# Altium schematic sheet Power.SchDoc(Power) (continued)

## Library data of the parts
C1 C4 = ESL107M035AE3AA: Cap Aluminum Lytic 100uF 35V 20%( 6.3 X 11mm) Radial 2.5mm 0.085 Ohm 545mA 8000h 105C Bag; Manufacturer: Yageo Group; ESR (Equivalent Series Resistance): 85mΩ; Leakage Current: 35µA; Ripple Current: 272.5mA; Value: 100µF; Case/Package: Radial; Category: Aluminum Electrolytic Capacitors; Component Kind: Standard; ComponentLink1Description: https://datasheet.octopart.com:443/ESL107M035AE3AA-KEMET-datasheet-182055926.pdf?src-supplier=IHS; ComponentLink1URL: https://datasheet.octopart.com:443/ESL107M035AE3AA-KEMET-datasheet-182055926.pdf?src-supplier=IHS; ComponentLink2Description: https://datasheet.octopart.com:443/ESL107M035AE3AA-KEMET-datasheet-182001356.pdf?src-supplier=Farnell; ComponentLink2URL: https://datasheet.octopart.com:443/ESL107M035AE3AA-KEMET-datasheet-182001356.pdf?src-supplier=Farnell; ComponentLink3Description: https://datasheet.octopart.com:443/ESL107M035AE3AA-KEMET-datasheet-130076494.pdf?src-supplier=element14+APAC; ComponentLink3URL: https://datasheet.octopart.com:443/ESL107M035AE3AA-KEMET-datasheet-130076494.pdf?src-supplier=element14+APAC; ComponentLink4Description: https://datasheet.octopart.com:443/ESL107M035AE3AA-KEMET-datasheet-176335210.pdf?src-supplier=Newark; ComponentLink4URL: https://datasheet.octopart.com:443/ESL107M035AE3AA-KEMET-datasheet-176335210.pdf?src-supplier=Newark; ComponentLink5Description: https://datasheet.octopart.com:443/ESL107M035AE3AA-KEMET-datasheet-182427411.pdf?src-supplier=Newark; ComponentLink5URL: https://datasheet.octopart.com:443/ESL107M035AE3AA-KEMET-datasheet-182427411.pdf?src-supplier=Newark; ComponentLink6Description: https://datasheet.octopart.com:443/ESL107M035AE3AA-KEMET-datasheet-160485627.pdf?src-supplier=TME; ComponentLink6URL: https://datasheet.octopart.com:443/ESL107M035AE3AA-KEMET-datasheet-160485627.pdf?src-supplier=TME; ComponentLink7Description: https://datasheet.octopart.com:443/ESL107M035AE3AA-KEMET-datasheet-160485613.pdf?src-supplier=TME; ComponentLink7URL: https://datasheet.octopart.com:443/ESL107M035AE3AA-KEMET-datasheet-160485613.pdf?src-supplier=TME; Diameter: 6.3mm; Height: 11mm; Length: 11mm; Lifecycle Status: Production; Manufacturer Part Number: ESL107M035AE3AA; Max Operating Temperature: 105°C; Min Operating Temperature: -40°C; Mounting Technology: Radial; Number of Terminals: 2; Packaging: Bulk; Pricing: 1=0.27, 10=0.161, 50=0.1168, 100=0.1025, 500=0.07794, 1000=0.07015, 2500=0.06181, 5000=0.05673 (USD); REACH SVHC: Unaffected; RoHS: Compliant; Schedule B: 8532220020; Stock: 137190; Supplier: DigiKey; Supplier Part Number: 399-15741-ND; Terminal Pitch: 2.5mm; Tolerance: 20%; Voltage Rating (DC): 35V; Library Name: Fuzz_Pedal_Lib.SchLib
C2 = GRM31CR71E106MA12L: 25V 10uF X7R ±20% 1206 Multilayer Ceramic Capacitors MLCC - SMD/SMT ROHS; Manufacturer: Murata; Manufacturer Lifecycle Status: IN PRODUCTION; Value: 10µF; Case Code (Imperial): 1206; Case Code (Metric): 3216; Case/Package: 1206; Category: Ceramic Capacitors; Component Kind: Standard; ComponentLink1Description: https://datasheet.octopart.com:443/GRM31CR71E106MA12L-Murata-datasheet-12559435.pdf?src-supplier=element14+APAC; ComponentLink1URL: https://datasheet.octopart.com:443/GRM31CR71E106MA12L-Murata-datasheet-12559435.pdf?src-supplier=element14+APAC; ComponentLink2Description: https://datasheet.octopart.com:443/GRM31CR71E106MA12L-Murata-datasheet-62317371.pdf?src-supplier=element14+APAC; ComponentLink2URL: https://datasheet.octopart.com:443/GRM31CR71E106MA12L-Murata-datasheet-62317371.pdf?src-supplier=element14+APAC; ComponentLink3Description: https://datasheet.octopart.com:443/GRM31CR71E106MA12L-Murata-datasheet-141280605.pdf?src-supplier=Geoflip; ComponentLink3URL: https://datasheet.octopart.com:443/GRM31CR71E106MA12L-Murata-datasheet-141280605.pdf?src-supplier=Geoflip; ComponentLink4Description: https://datasheet.octopart.com:443/GRM31CR71E106MA12L-Murata-datasheet-165579666.pdf?src-supplier=TME; ComponentLink4URL: https://datasheet.octopart.com:443/GRM31CR71E106MA12L-Murata-datasheet-165579666.pdf?src-supplier=TME; ComponentLink5Description: https://datasheet.octopart.com:443/GRM31CR71E106MA12L-Murata-datasheet-140709145.pdf?src-supplier=Ciiva; ComponentLink5URL: https://datasheet.octopart.com:443/GRM31CR71E106MA12L-Murata-datasheet-140709145.pdf?src-supplier=Ciiva; ComponentLink6Description: https://datasheet.octopart.com:443/GRM31CR71E106MA12L-Murata-datasheet-138746392.pdf?src-supplier=Ciiva; ComponentLink6URL: https://datasheet.octopart.com:443/GRM31CR71E106MA12L-Murata-datasheet-138746392.pdf?src-supplier=Ciiva; ComponentLink7Description: https://datasheet.octopart.com:443/GRM31CR71E106MA12L-Murata-datasheet-22122223.pdf?src-supplier=Future+Electronics; ComponentLink7URL: https://datasheet.octopart.com:443/GRM31CR71E106MA12L-Murata-datasheet-22122223.pdf?src-supplier=Future+Electronics; ComponentLink8Description: https://datasheet.octopart.com/GRM31CR71E106MA12L-Murata-datasheet-12515295.pdf; ComponentLink8URL: https://datasheet.octopart.com/GRM31CR71E106MA12L-Murata-datasheet-12515295.pdf; ComponentLink9Description: https://datasheet.octopart.com/GRM31CR71E106MA12L-Murata-datasheet-12510076.pdf; ComponentLink9URL: https://datasheet.octopart.com/GRM31CR71E106MA12L-Murata-datasheet-12510076.pdf; ComponentLink10Description: https://datasheet.octopart.com/GRM31CR71E106MA12L-Murata-datasheet-11978611.pdf; ComponentLink10URL: https://datasheet.octopart.com/GRM31CR71E106MA12L-Murata-datasheet-11978611.pdf; ComponentLink11Description: https://datasheet.octopart.com/GRM31CR71E106MA12L-Murata-datasheet-10025737.pdf; ComponentLink11URL: https://datasheet.octopart.com/GRM31CR71E106MA12L-Murata-datasheet-10025737.pdf; ComponentLink12Description: https://datasheet.octopart.com/GRM31CR71E106MA12L-Murata-datasheet-8819587.pdf; ComponentLink12URL: https://datasheet.octopart.com/GRM31CR71E106MA12L-Murata-datasheet-8819587.pdf; ComponentLink13Description: https://datasheet.octopart.com/GRM31CR71E106MA12L-Murata-datasheet-14397760.pdf; ComponentLink13URL: https://datasheet.octopart.com/GRM31CR71E106MA12L-Murata-datasheet-14397760.pdf; ComponentLink14Description: https://datasheet.octopart.com:443/GRM31CR71E106MA12L-Murata-datasheet-150009226.pdf?src-supplier=Arrow.cn; ComponentLink14URL: https://datasheet.octopart.com:443/GRM31CR71E106MA12L-Murata-datasheet-150009226.pdf?src-supplier=Arrow.cn; ComponentLink15Description: http://sigma.octopart.com:80/reach_statement/Murata-company-16.pdf?src-supplier=Compliance+Statements; ComponentLink15URL: http://sigma.octopart.com:80/reach_statement/Murata-company-16.pdf?src-supplier=Compliance+Statements; Depth: 1.6mm; Dielectric: X7R; Dielectric Material: Ceramic; Export Control Classification Number (ECCN) Code: EAR99; Harmonized Tariff Schedule (HTS) Code: 8532.24.00.20; Height: 1.6mm; Introduction Date: 2008-08-22; Lead Free: Lead Free; Length: 3.2mm; Lifecycle Status: Production; Manufacturer Part Number: GRM31CR71E106MA12L; Material: Ceramic; Max Operating Temperature: 125°C; Min Operating Temperature: -55°C; Mounting Technology: Surface Mount; Number of Terminals: 2; Packaging: Cut Tape; Pricing: 1=0.35, 10=0.205, 50=0.1492, 100=0.1321, 500=0.10336 (USD); Radiation Hardening: No; REACH SVHC: Unaffected; RoHS: Compliant; Schedule B: 8532240020, 8532240020|8532240020, 8532240020|8532240020|8532240020, 8532240020|8532240020|8532240020|8532240020; Stock: 60425; Supplier: DigiKey; Supplier Part Number: 490-6519-6-ND; Termination: SMD/SMT; Thickness: 1.6mm; Tolerance: 20%; Voltage: 25V; Voltage Rating: 25V; Voltage Rating (DC): 25V; Width: 1.6mm; Library Name: Fuzz_Pedal_Lib.SchLib
C3 C5 = C0805C104K5RACTU: 50V 100nF X7R ±10% 0805 Multilayer Ceramic Capacitors MLCC - SMD/SMT ROHS; Manufacturer: Yageo Group; Temperature Coefficient: 30ppm/°C; Voltage: 500V; Case Code (Imperial): 0805; Case/Package: 0805; Category: Ceramic Capacitors; China RoHS: Compliant; Component Kind: Standard; ComponentLink1Description: https://datasheet.octopart.com:443/C0805C104K5RACTU-KEMET-datasheet-178445679.pdf?src-supplier=IHS; ComponentLink1URL: https://datasheet.octopart.com:443/C0805C104K5RACTU-KEMET-datasheet-178445679.pdf?src-supplier=IHS; ComponentLink2Description: https://datasheet.octopart.com:443/C0805C104K5RAC7800-KEMET-datasheet-182041128.pdf?src-supplier=IHS; ComponentLink2URL: https://datasheet.octopart.com:443/C0805C104K5RAC7800-KEMET-datasheet-182041128.pdf?src-supplier=IHS; ComponentLink3Description: https://datasheet.octopart.com:443/C0805C104K5RACTU-KEMET-datasheet-137357759.pdf?src-supplier=Upverter; ComponentLink3URL: https://datasheet.octopart.com:443/C0805C104K5RACTU-KEMET-datasheet-137357759.pdf?src-supplier=Upverter; ComponentLink4Description: https://datasheet.octopart.com:443/C0805C104K5RACTU-KEMET-datasheet-68331921.pdf?src-supplier=Upverter; ComponentLink4URL: https://datasheet.octopart.com:443/C0805C104K5RACTU-KEMET-datasheet-68331921.pdf?src-supplier=Upverter; ComponentLink5Description: https://datasheet.octopart.com:443/C0805C104K5RAC-TU-Kemet-datasheet-5313890.pdf?src-supplier=Newark; ComponentLink5URL: https://datasheet.octopart.com:443/C0805C104K5RAC-TU-Kemet-datasheet-5313890.pdf?src-supplier=Newark; ComponentLink6Description: https://datasheet.octopart.com:443/C0805C104K5RAC-TU-Kemet-datasheet-5390537.pdf?src-supplier=Newark; ComponentLink6URL: https://datasheet.octopart.com:443/C0805C104K5RAC-TU-Kemet-datasheet-5390537.pdf?src-supplier=Newark; ComponentLink7Description: https://datasheet.octopart.com:443/C0805C104K5RAC-TU-Kemet-datasheet-7541751.pdf?src-supplier=Newark; ComponentLink7URL: https://datasheet.octopart.com:443/C0805C104K5RAC-TU-Kemet-datasheet-7541751.pdf?src-supplier=Newark; ComponentLink8Description: https://datasheet.octopart.com:443/C0805C104K5RACTU-KEMET-datasheet-170667449.pdf?src-supplier=Newark; ComponentLink8URL: https://datasheet.octopart.com:443/C0805C104K5RACTU-KEMET-datasheet-170667449.pdf?src-supplier=Newark; ComponentLink9Description: https://datasheet.octopart.com:443/C0805C104K5RACTU-Kemet-datasheet-14356739.pdf?src-supplier=Newark; ComponentLink9URL: https://datasheet.octopart.com:443/C0805C104K5RACTU-Kemet-datasheet-14356739.pdf?src-supplier=Newark; ComponentLink10Description: https://datasheet.octopart.com:443/C0805C104K5RACTU-Kemet-datasheet-8764837.pdf?src-supplier=Newark; ComponentLink10URL: https://datasheet.octopart.com:443/C0805C104K5RACTU-Kemet-datasheet-8764837.pdf?src-supplier=Newark; ComponentLink11Description: https://datasheet.octopart.com:443/C0805C104K5RACTU-Kemet-datasheet-8480123.pdf?src-supplier=Newark; ComponentLink11URL: https://datasheet.octopart.com:443/C0805C104K5RACTU-Kemet-datasheet-8480123.pdf?src-supplier=Newark; ComponentLink12Description: https://datasheet.octopart.com:443/C0805C104K5RACTU-Kemet-datasheet-5393970.pdf?src-supplier=Newark; ComponentLink12URL: https://datasheet.octopart.com:443/C0805C104K5RACTU-Kemet-datasheet-5393970.pdf?src-supplier=Newark; ComponentLink13Description: https://datasheet.octopart.com:443/C0805C104K5RACTU-Kemet-datasheet-8502025.pdf?src-supplier=Newark; ComponentLink13URL: https://datasheet.octopart.com:443/C0805C104K5RACTU-Kemet-datasheet-8502025.pdf?src-supplier=Newark; ComponentLink14Description: https://datasheet.octopart.com:443/C0805C104K5RACTU-Kemet-datasheet-161801.pdf?src-supplier=Newark; ComponentLink14URL: https://datasheet.octopart.com:443/C0805C104K5RACTU-Kemet-datasheet-161801.pdf?src-supplier=Newark; ComponentLink15Description: https://datasheet.octopart.com:443/C0805C104K5RACTU-KEMET-datasheet-101576148.pdf?src-supplier=Farnell; ComponentLink15URL: https://datasheet.octopart.com:443/C0805C104K5RACTU-KEMET-datasheet-101576148.pdf?src-supplier=Farnell; ComponentLink16Description: https://datasheet.octopart.com:443/C0805C104K5RACTU-KEMET-datasheet-176142297.pdf?src-supplier=Farnell; ComponentLink16URL: https://datasheet.octopart.com:443/C0805C104K5RACTU-KEMET-datasheet-176142297.pdf?src-supplier=Farnell; ComponentLink17Description: https://datasheet.octopart.com:443/C0805C104K5RAC-TU-Kemet-datasheet-12608295.pdf?src-supplier=Farnell; ComponentLink17URL: https://datasheet.octopart.com:443/C0805C104K5RAC-TU-Kemet-datasheet-12608295.pdf?src-supplier=Farnell; ComponentLink18Description: https://datasheet.octopart.com:443/C0805C104K5RAC-TU-Kemet-datasheet-11992184.pdf?src-supplier=Farnell; ComponentLink18URL: https://datasheet.octopart.com:443/C0805C104K5RAC-TU-Kemet-datasheet-11992184.pdf?src-supplier=Farnell; ComponentLink19Description: https://datasheet.octopart.com:443/C0805C104K5RAC-TU-Kemet-datasheet-10942409.pdf?src-supplier=Farnell; ComponentLink19URL: https://datasheet.octopart.com:443/C0805C104K5RAC-TU-Kemet-datasheet-10942409.pdf?src-supplier=Farnell; ComponentLink20Description: https://datasheet.octopart.com:443/C0805C104K5RAC-TU-Kemet-datasheet-10404442.pdf?src-supplier=Farnell; ComponentLink20URL: https://datasheet.octopart.com:443/C0805C104K5RAC-TU-Kemet-datasheet-10404442.pdf?src-supplier=Farnell; ComponentLink21Description: https://datasheet.octopart.com:443/C0805C104K5RAC-TU-Kemet-datasheet-7833651.pdf?src-supplier=Farnell; ComponentLink21URL: https://datasheet.octopart.com:443/C0805C104K5RAC-TU-Kemet-datasheet-7833651.pdf?src-supplier=Farnell; ComponentLink22Description: https://datasheet.octopart.com:443/C0805C104K5RAC-TU-Kemet-datasheet-7601896.pdf?src-supplier=Farnell; ComponentLink22URL: https://datasheet.octopart.com:443/C0805C104K5RAC-TU-Kemet-datasheet-7601896.pdf?src-supplier=Farnell; ComponentLink23Description: https://datasheet.octopart.com:443/C0805C104K5RAC-TU-Kemet-datasheet-5316294.pdf?src-supplier=Farnell; ComponentLink23URL: https://datasheet.octopart.com:443/C0805C104K5RAC-TU-Kemet-datasheet-5316294.pdf?src-supplier=Farnell; ComponentLink24Description: https://datasheet.octopart.com:443/C0805C104K5RAC-TU-Kemet-datasheet-5314837.pdf?src-supplier=Farnell; ComponentLink24URL: https://datasheet.octopart.com:443/C0805C104K5RAC-TU-Kemet-datasheet-5314837.pdf?src-supplier=Farnell; ComponentLink25Description: https://datasheet.octopart.com:443/C0805C104K5RAC-TU-Kemet-datasheet-8092359.pdf?src-supplier=Farnell; ComponentLink25URL: https://datasheet.octopart.com:443/C0805C104K5RAC-TU-Kemet-datasheet-8092359.pdf?src-supplier=Farnell; ComponentLink26Description: https://datasheet.octopart.com:443/C0805C104K5RACTU-Kemet-datasheet-5314449.pdf?src-supplier=Farnell; ComponentLink26URL: https://datasheet.octopart.com:443/C0805C104K5RACTU-Kemet-datasheet-5314449.pdf?src-supplier=Farnell; ComponentLink27Description: https://datasheet.octopart.com:443/C0805C104K5RAC-TU-Kemet-datasheet-5314969.pdf?src-supplier=Farnell; ComponentLink27URL: https://datasheet.octopart.com:443/C0805C104K5RAC-TU-Kemet-datasheet-5314969.pdf?src-supplier=Farnell; ComponentLink28Description: https://datasheet.octopart.com:443/C0805C104K5RAC-TU-Kemet-datasheet-5314627.pdf?src-supplier=Farnell; ComponentLink28URL: https://datasheet.octopart.com:443/C0805C104K5RAC-TU-Kemet-datasheet-5314627.pdf?src-supplier=Farnell; ComponentLink29Description: https://datasheet.octopart.com:443/C0805C104K5RAC-TU-Kemet-datasheet-5389132.pdf?src-supplier=Farnell; ComponentLink29URL: https://datasheet.octopart.com:443/C0805C104K5RAC-TU-Kemet-datasheet-5389132.pdf?src-supplier=Farnell; ComponentLink30Description: https://datasheet.octopart.com:443/C0805C104K5RAC-TU-Kemet-datasheet-5313836.pdf?src-supplier=Farnell; ComponentLink30URL: https://datasheet.octopart.com:443/C0805C104K5RAC-TU-Kemet-datasheet-5313836.pdf?src-supplier=Farnell; ComponentLink31Description: https://datasheet.octopart.com:443/C0805C104K5RAC-TU-Kemet-datasheet-8111010.pdf?src-supplier=Farnell; ComponentLink31URL: https://datasheet.octopart.com:443/C0805C104K5RAC-TU-Kemet-datasheet-8111010.pdf?src-supplier=Farnell; ComponentLink32Description: https://datasheet.octopart.com:443/C0805C104K5RAC-TU-Kemet-datasheet-7627733.pdf?src-supplier=Farnell; ComponentLink32URL: https://datasheet.octopart.com:443/C0805C104K5RAC-TU-Kemet-datasheet-7627733.pdf?src-supplier=Farnell; ComponentLink33Description: https://datasheet.octopart.com:443/C0805C104K5RAC-TU-Kemet-datasheet-5315946.pdf?src-supplier=Farnell; ComponentLink33URL: https://datasheet.octopart.com:443/C0805C104K5RAC-TU-Kemet-datasheet-5315946.pdf?src-supplier=Farnell; ComponentLink34Description: https://datasheet.octopart.com:443/C0805C104K5RACTU-KEMET-datasheet-37550250.pdf?src-supplier=Electro+Sonic; ComponentLink34URL: https://datasheet.octopart.com:443/C0805C104K5RACTU-KEMET-datasheet-37550250.pdf?src-supplier=Electro+Sonic; ComponentLink35Description: https://datasheet.octopart.com:443/C0805C104K5RAC-TU-Kemet-datasheet-32036385.pdf?src-supplier=element14+APAC; ComponentLink35URL: https://datasheet.octopart.com:443/C0805C104K5RAC-TU-Kemet-datasheet-32036385.pdf?src-supplier=element14+APAC; ComponentLink36Description: https://datasheet.octopart.com:443/C0805C104K5RACTU-Kemet-datasheet-22975000.pdf?src-supplier=element14+APAC; ComponentLink36URL: https://datasheet.octopart.com:443/C0805C104K5RACTU-Kemet-datasheet-22975000.pdf?src-supplier=element14+APAC; ComponentLink37Description: https://datasheet.octopart.com:443/C0805C104K5RACTU-Kemet-datasheet-22084244.pdf?src-supplier=element14+APAC; ComponentLink37URL: https://datasheet.octopart.com:443/C0805C104K5RACTU-Kemet-datasheet-22084244.pdf?src-supplier=element14+APAC; ComponentLink38Description: https://datasheet.octopart.com:443/C0805C104K5RAC7800-KEMET-datasheet-188512054.pdf?src-supplier=ODG+(Origin+Data+Global); ComponentLink38URL: https://datasheet.octopart.com:443/C0805C104K5RAC7800-KEMET-datasheet-188512054.pdf?src-supplier=ODG+(Origin+Data+Global); ComponentLink39Description: https://datasheet.octopart.com:443/C0805C104K5RACTU-KEMET-datasheet-164512540.pdf?src-supplier=TME; ComponentLink39URL: https://datasheet.octopart.com:443/C0805C104K5RACTU-KEMET-datasheet-164512540.pdf?src-supplier=TME; ComponentLink40Description: https://datasheet.octopart.com:443/C0805C104K5RACTU-Kemet-datasheet-67847106.pdf?src-supplier=TME; ComponentLink40URL: https://datasheet.octopart.com:443/C0805C104K5RACTU-Kemet-datasheet-67847106.pdf?src-supplier=TME; ComponentLink41Description: https://datasheet.octopart.com:443/C0805C104K5RACTU-KEMET-datasheet-68331906.pdf?src-supplier=TME; ComponentLink41URL: https://datasheet.octopart.com:443/C0805C104K5RACTU-KEMET-datasheet-68331906.pdf?src-supplier=TME; ComponentLink42Description: https://datasheet.octopart.com:443/C0805C104K5RACTU-KEMET-datasheet-110724412.pdf?src-supplier=RS+(Formerly+Allied+Electronics); ComponentLink42URL: https://datasheet.octopart.com:443/C0805C104K5RACTU-KEMET-datasheet-110724412.pdf?src-supplier=RS+(Formerly+Allied+Electronics); ComponentLink43Description: https://datasheet.octopart.com:443/C0805C104K5RACTU-Kemet-datasheet-464.pdf?src-supplier=RS+(Formerly+Allied+Electronics); ComponentLink43URL: https://datasheet.octopart.com:443/C0805C104K5RACTU-Kemet-datasheet-464.pdf?src-supplier=RS+(Formerly+Allied+Electronics); ComponentLink44Description: https://datasheet.octopart.com/C0805C104K5RACTU-KEMET-datasheet-84169754.pdf; ComponentLink44URL: https://datasheet.octopart.com/C0805C104K5RACTU-KEMET-datasheet-84169754.pdf; ComponentLink45Description: https://datasheet.octopart.com:443/C0805C104K5RACTU-Kemet-datasheet-13693438.pdf?src-supplier=Factory+Futures; ComponentLink45URL: https://datasheet.octopart.com:443/C0805C104K5RACTU-Kemet-datasheet-13693438.pdf?src-supplier=Factory+Futures; ComponentLink46Description: https://datasheet.octopart.com:443/C0805C104K5RAC7800-KEMET-datasheet-164857143.pdf?src-supplier=Burklin+Elektronik; ComponentLink46URL: https://datasheet.octopart.com:443/C0805C104K5RAC7800-KEMET-datasheet-164857143.pdf?src-supplier=Burklin+Elektronik; ComponentLink47Description: http://sigma.octopart.com:80/conflict_mineral_statement/Yageo-company-3.pdf?src-supplier=Compliance+Statements; ComponentLink47URL: http://sigma.octopart.com:80/conflict_mineral_statement/Yageo-company-3.pdf?src-supplier=Compliance+Statements; ComponentLink48Description: http://sigma.octopart.com:80/rohs_statement/Yageo-company-2.pdf?src-supplier=Compliance+Statements; ComponentLink48URL: http://sigma.octopart.com:80/rohs_statement/Yageo-company-2.pdf?src-supplier=Compliance+Statements; Contact Plating: Tin; Country of Origin: Mainland China; Depth: 1.25mm; Dielectric: X7R; Dielectric Material: Ceramic; Export Control Classification Number (ECCN) Code: EAR99; Harmonized Tariff Schedule (HTS) Code: 8532.24.00.20; Height: 780µm; Introduction Date: 2001-04-27; Lead Free: Lead Free; Lead Pitch: 750µm; Length: 2mm; Lifecycle Status: Production; Manufacturer Part Number: C0805C104K5RACTU; Material: Ceramic; Max Operating Temperature: 125°C; Min Operating Temperature: -55°C; Mounting Technology: Surface Mount; Number of Terminals: 2; Packaging: Cut Tape; Pins: 2; Pricing: 1=0.18, 10=0.1, 50=0.07, 100=0.0608, 500=0.0454 (USD); Radiation Hardening: No; REACH SVHC: Unaffected; RoHS: Compliant; Schedule B: 8532240020, 8532240020|8532240020, 8532240020|8532240020|8532240020, 8532240020|8532240020|8532240020|8532240020; Stock: 6696372; Supplier: DigiKey; Supplier Part Number: 399-C0805C104K5RACTUDKR-ND; Termination: SMD/SMT; Thickness: 889µm; Tolerance: 10%; Value: 100nF; Voltage Rating: 50V; Voltage Rating (DC): 50V; Width: 1.25mm; Library Name: Fuzz_Pedal_Lib.SchLib
D1 D2 = WP1503GT: Single Color LED, Green, Transparent, T-1 3/4, 5mm; Manufacturer: Kingbright; Dominant Wavelength: 568nm; Forward Current: 25mA; Forward Voltage: 2.2V; Lens Style: Clear, Dome, Tinted; Luminous Intensity: 60mcd; Number of Circuits: 1; Peak Wavelength: 565nm; Shape: Round; Test Current: 20mA; Viewing Angle: 30°; Case/Package: Radial; Category: LEDs; China RoHS: Compliant; Color: Green; Component Kind: Standard; ComponentLink1Description: https://datasheet.octopart.com:443/WP1503GT-Kingbright-datasheet-180525248.pdf?src-supplier=IHS; ComponentLink1URL: https://datasheet.octopart.com:443/WP1503GT-Kingbright-datasheet-180525248.pdf?src-supplier=IHS; ComponentLink2Description: https://datasheet.octopart.com:443/WP1503GT-Kingbright-datasheet-65593620.pdf?src-supplier=IHS; ComponentLink2URL: https://datasheet.octopart.com:443/WP1503GT-Kingbright-datasheet-65593620.pdf?src-supplier=IHS; ComponentLink3Description: https://datasheet.octopart.com:443/WP1503GT-Kingbright-datasheet-137343189.pdf?src-supplier=Kingbright+SCT; ComponentLink3URL: https://datasheet.octopart.com:443/WP1503GT-Kingbright-datasheet-137343189.pdf?src-supplier=Kingbright+SCT; Country of Origin: Mainland China; Harmonized Tariff Schedule (HTS) Code: 8541.40.20.00; Height: 8.6mm; Illumination Color: Green; Introduction Date: 2005-04-16; Lens Color: Green; Lifecycle Status: Production; Manufacturer Part Number: WP1503GT; Max Operating Temperature: 85°C; Min Operating Temperature: -40°C; Mounting Technology: Through Hole; Number of Terminals: 2; Packaging: Bulk; Pricing: 1=0.24, 10=0.166, 100=0.116, 500=0.09322, 1000=0.08569, 2000=0.07926, 5000=0.0722, 20000=0.06403, 40000=0.06085 (USD); REACH SVHC: Yes; RoHS: Compliant; Stock: 14499; Supplier: DigiKey; Supplier Part Number: WP1503GT-ND; Terminal Pitch: 2.54mm; Wavelength: 568nm; Library Name: EcoCar_Accessory_Controller_V2.IntLib
D3 = SAC10-E3/54: 500 W 10 V Uni Directional Axial Transient Voltage Suppressor - DO-204AC; Manufacturer: Vishay; Breakdown Voltage: 11.1V; Clamping Voltage: 1V; Element Configuration: Single; Leakage Current: 5µA; Max Power Dissipation: 3W; Max Surge Current: 29A; Number of Channels: 1; Operating Supply Voltage: 10V; Peak Pulse Power: 500W; Test Current: 1mA; Value: 50pF; Case/Package: DO-15; Category: TVS Diodes; China RoHS: Non-Compliant; Component Kind: Standard; ComponentLink1Description: https://datasheet.octopart.com:443/SAC10-E3-54-Vishay-datasheet-181470670.pdf?src-supplier=Newark; ComponentLink1URL: https://datasheet.octopart.com:443/SAC10-E3-54-Vishay-datasheet-181470670.pdf?src-supplier=Newark; ComponentLink2Description: https://datasheet.octopart.com:443/SAC10-E3/54-Vishay-datasheet-11555040.pdf?src-supplier=Newark; ComponentLink2URL: https://datasheet.octopart.com:443/SAC10-E3/54-Vishay-datasheet-11555040.pdf?src-supplier=Newark; ComponentLink3Description: https://datasheet.octopart.com:443/SAC10-E3/54-Vishay-datasheet-8373145.pdf?src-supplier=Newark; ComponentLink3URL: https://datasheet.octopart.com:443/SAC10-E3/54-Vishay-datasheet-8373145.pdf?src-supplier=Newark; ComponentLink4Description: https://datasheet.octopart.com:443/SAC10-E3/54-Vishay-datasheet-5316468.pdf?src-supplier=Newark; ComponentLink4URL: https://datasheet.octopart.com:443/SAC10-E3/54-Vishay-datasheet-5316468.pdf?src-supplier=Newark; ComponentLink5Description: https://datasheet.octopart.com:443/SAC10-E3-54-Vishay-datasheet-78862583.pdf?src-supplier=Newark; ComponentLink5URL: https://datasheet.octopart.com:443/SAC10-E3-54-Vishay-datasheet-78862583.pdf?src-supplier=Newark; ComponentLink6Description: https://datasheet.octopart.com:443/SAC10-E3-54-Vishay-datasheet-33014114.pdf?src-supplier=Newark; ComponentLink6URL: https://datasheet.octopart.com:443/SAC10-E3-54-Vishay-datasheet-33014114.pdf?src-supplier=Newark; ComponentLink7Description: http://sigma.octopart.com:80/rohs_statement/Vishay-company-15.pdf?src-supplier=Compliance+Statements; ComponentLink7URL: http://sigma.octopart.com:80/rohs_statement/Vishay-company-15.pdf?src-supplier=Compliance+Statements; Direction: Unidirectional; Lead Free: Lead Free; Lifecycle Status: Production; Manufacturer Part Number: SAC10-E3/54; Max Operating Temperature: 175°C; Max Reverse Leakage Current: 5µA; Min Breakdown Voltage: 11.1V; Min Operating Temperature: -55°C; Mounting Technology: Through Hole; Number of Elements: 1; Number of Terminals: 2; Peak Pulse Current: 29A; Pins: 2; Polarity: Unidirectional; Power Dissipation: 500W; Pricing: 1=1.96, 10=1.247, 50=0.9376, 100=0.8377, 500=0.66244 (USD); REACH SVHC: Affected; Reverse Breakdown Voltage: 11.1V; Reverse Standoff Voltage: 10V; RoHS: Compliant; Stock: 365; Supplier: DigiKey; Supplier Part Number: 112-SAC10-E3/54CT-ND; Termination: Axial; Library Name: Tremolo_Pedal.IntLib
FB1 = MPZ2012S601AT000: Ferrite Beads Multi-Layer Power 600Ohm 25% 100MHz 2A 0.1Ohm DCR 0805 T/R; Manufacturer: TDK; Manufacturer Lifecycle Status: PRODUCTION; Manufacturer Package Identifier: 0805 (2012 metric); DC Resistance (DCR): 100mΩ; Impedance: 600Ω; Number of Circuits: 1; Value: 100mΩ; Case Code (Imperial): 0805; Case Code (Metric): 2012; Case/Package: 0805; Category: Ferrite Beads and Chips; China RoHS: Compliant; Clock Frequency: 100MHz; Component Kind: Standard; ComponentLink1Description: https://datasheet.octopart.com:443/MPZ2012S601AT000-TDK-datasheet-155380320.pdf?src-supplier=ODG+(Origin+Data+Global); ComponentLink1URL: https://datasheet.octopart.com:443/MPZ2012S601AT000-TDK-datasheet-155380320.pdf?src-supplier=ODG+(Origin+Data+Global); ComponentLink2Description: https://datasheet.octopart.com:443/MPZ2012S601AT000-TDK-datasheet-166558464.pdf?src-supplier=Farnell; ComponentLink2URL: https://datasheet.octopart.com:443/MPZ2012S601AT000-TDK-datasheet-166558464.pdf?src-supplier=Farnell; ComponentLink3Description: https://datasheet.octopart.com:443/MPZ2012S601AT000-TDK-datasheet-12512619.pdf?src-supplier=Farnell; ComponentLink3URL: https://datasheet.octopart.com:443/MPZ2012S601AT000-TDK-datasheet-12512619.pdf?src-supplier=Farnell; ComponentLink4Description: https://datasheet.octopart.com:443/MPZ2012S601AT000-TDK-datasheet-8365394.pdf?src-supplier=Farnell; ComponentLink4URL: https://datasheet.octopart.com:443/MPZ2012S601AT000-TDK-datasheet-8365394.pdf?src-supplier=Farnell; ComponentLink5Description: https://datasheet.octopart.com:443/MPZ2012S601AT000-TDK-datasheet-7554990.pdf?src-supplier=Farnell; ComponentLink5URL: https://datasheet.octopart.com:443/MPZ2012S601AT000-TDK-datasheet-7554990.pdf?src-supplier=Farnell; ComponentLink6Description: https://datasheet.octopart.com:443/MPZ2012S601AT000-TDK-datasheet-39118740.pdf?src-supplier=Upverter; ComponentLink6URL: https://datasheet.octopart.com:443/MPZ2012S601AT000-TDK-datasheet-39118740.pdf?src-supplier=Upverter; ComponentLink7Description: https://datasheet.octopart.com:443/MPZ2012S601AT000-TDK-datasheet-82475261.pdf?src-supplier=Newark; ComponentLink7URL: https://datasheet.octopart.com:443/MPZ2012S601AT000-TDK-datasheet-82475261.pdf?src-supplier=Newark; ComponentLink8Description: https://datasheet.octopart.com:443/MPZ2012S601AT000-TDK-datasheet-41739209.pdf?src-supplier=Newark; ComponentLink8URL: https://datasheet.octopart.com:443/MPZ2012S601AT000-TDK-datasheet-41739209.pdf?src-supplier=Newark; ComponentLink9Description: https://datasheet.octopart.com:443/MPZ2012S601AT000-TDK-datasheet-21218011.pdf?src-supplier=Newark; ComponentLink9URL: https://datasheet.octopart.com:443/MPZ2012S601AT000-TDK-datasheet-21218011.pdf?src-supplier=Newark; ComponentLink10Description: https://datasheet.octopart.com:443/MPZ2012S601AT000-TDK-datasheet-21631858.pdf?src-supplier=Future+Electronics; ComponentLink10URL: https://datasheet.octopart.com:443/MPZ2012S601AT000-TDK-datasheet-21631858.pdf?src-supplier=Future+Electronics; ComponentLink11Description: https://datasheet.octopart.com:443/MPZ2012S601AT000-TDK-datasheet-62109747.pdf?src-supplier=Electro+Sonic; ComponentLink11URL: https://datasheet.octopart.com:443/MPZ2012S601AT000-TDK-datasheet-62109747.pdf?src-supplier=Electro+Sonic; ComponentLink12Description: https://datasheet.octopart.com:443/MPZ2012S601AT000-TDK-datasheet-155118627.pdf?src-supplier=Master+Electronics; ComponentLink12URL: https://datasheet.octopart.com:443/MPZ2012S601AT000-TDK-datasheet-155118627.pdf?src-supplier=Master+Electronics; Core Material: Ferrite; Current Rating: 2A; ESR: 100mΩ; Export Control Classification Number (ECCN) Code: EAR99; Harmonized Tariff Schedule (HTS) Code: 8504.50.80.00; Height: 850µm; Introduction Date: 2009-03-27; Lead Free: Lead Free; Length: 2mm; Lifecycle Status: Production; Manufacturer Part Number: MPZ2012S601AT000; Max DC Current: 2A; Max Length: 2.2mm; Max Operating Temperature: 125°C; Max Width: 1.45mm; Min Length: 1.8mm; Min Operating Temperature: -55°C; Min Width: 1.05mm; Mounting Technology: Surface Mount; Packaging: Tape and Reel; Pricing: 1=0.11, 10=0.077, 25=0.0664, 50=0.0598, 100=0.0536, 250=0.04612, 500=0.04098, 1000=0.03622, 4000=0.02695, 8000=0.02325, 12000=0.02225 (USD); REACH SVHC: Unaffected; RMS Current (Irms): 2A; RoHS: Compliant; Schedule B: 8504500000, 8504500000|8504500000|8504500000|8504500000|8504500000; Stock: 1114236; Supplier: DigiKey; Supplier Part Number: 445-MPZ2012S601AT000CT-ND; Test Frequency: 100MHz; Tolerance: 25%; Width: 1.25mm; Library Name: Fuzz_Pedal_Lib.SchLib
J1 = 54-00129: Conn DC Power RCP 2 POS Solder RA Thru-Hole 3 Terminal 1 Port; Manufacturer: Tensility; Current Rating: 6A; Voltage Rating: 48V; Category: Connectors; Component Kind: Standard; ComponentLink1Description: https://datasheet.octopart.com:443/54-00129-Tensility-datasheet-189118334.pdf?src-supplier=Master+Electronics; ComponentLink1URL: https://datasheet.octopart.com:443/54-00129-Tensility-datasheet-189118334.pdf?src-supplier=Master+Electronics; Contact Material: Brass; Export Control Classification Number (ECCN) Code: EAR99; Harmonized Tariff Schedule (HTS) Code: 8536.69.40.20; Manufacturer Part Number: 54-00129; Max Operating Temperature: 85°C; Min Operating Temperature: -25°C; Pricing: 1=0.88, 10=0.745, 25=0.6976, 50=0.6644, 100=0.6326, 250=0.59296, 500=0.56462, 1000=0.53765, 2500=0.50399 (USD); REACH SVHC: Affected; RoHS: Compliant; Schedule B: 8536695050, 8536695050|8536695050|8536695050|8536695050|8536695050; Stock: 18126; Supplier: DigiKey; Supplier Part Number: 839-54-00129-ND; Termination: Solder; Library Name: Tremolo_Pedal.IntLib
R1 R2 = RC0805FR-0710KL: 10 kOhms ±1% 0.125W, 1/8W Chip Resistor 0805 (2012 Metric) Moisture Resistant Thick Film; Manufacturer: Yageo Group; Max Power Dissipation: 125mW; Temperature Coefficient: 100ppm/°C; Value: 10kΩ; Voltage Rating: 150V; Case Code (Imperial): 0805; Case/Package: 0805; Category: Chip SMD Resistors; China RoHS: Non-Compliant; Component Kind: Standard; ComponentLink1Description: https://datasheet.octopart.com:443/RC0805FR-0710KL-Yageo-datasheet-175887523.pdf?src-supplier=Newark; ComponentLink1URL: https://datasheet.octopart.com:443/RC0805FR-0710KL-Yageo-datasheet-175887523.pdf?src-supplier=Newark; ComponentLink2Description: https://datasheet.octopart.com:443/RC0805FR-0710KL-Yageo-datasheet-62067350.pdf?src-supplier=Farnell; ComponentLink2URL: https://datasheet.octopart.com:443/RC0805FR-0710KL-Yageo-datasheet-62067350.pdf?src-supplier=Farnell; ComponentLink3Description: https://datasheet.octopart.com:443/RC0805FR-0710KL-Yageo-datasheet-13691069.pdf?src-supplier=Farnell; ComponentLink3URL: https://datasheet.octopart.com:443/RC0805FR-0710KL-Yageo-datasheet-13691069.pdf?src-supplier=Farnell; ComponentLink4Description: https://datasheet.octopart.com:443/RC0805FR-0710KL-Yageo-datasheet-103092701.pdf?src-supplier=Upverter; ComponentLink4URL: https://datasheet.octopart.com:443/RC0805FR-0710KL-Yageo-datasheet-103092701.pdf?src-supplier=Upverter; ComponentLink5Description: https://datasheet.octopart.com:443/RC0805FR-0710KL-Yageo-datasheet-98239111.pdf?src-supplier=Upverter; ComponentLink5URL: https://datasheet.octopart.com:443/RC0805FR-0710KL-Yageo-datasheet-98239111.pdf?src-supplier=Upverter; ComponentLink6Description: https://datasheet.octopart.com:443/RC0805FR-0710KL-Yageo---Phycomp-datasheet-67302840.pdf?src-supplier=Upverter; ComponentLink6URL: https://datasheet.octopart.com:443/RC0805FR-0710KL-Yageo---Phycomp-datasheet-67302840.pdf?src-supplier=Upverter; ComponentLink7Description: https://datasheet.octopart.com:443/RC0805FR-0710KL-Yageo-datasheet-8330313.pdf?src-supplier=element14+APAC; ComponentLink7URL: https://datasheet.octopart.com:443/RC0805FR-0710KL-Yageo-datasheet-8330313.pdf?src-supplier=element14+APAC; ComponentLink8Description: https://datasheet.octopart.com:443/RC0805FR-0710KL-Yageo-datasheet-12592811.pdf?src-supplier=element14+APAC; ComponentLink8URL: https://datasheet.octopart.com:443/RC0805FR-0710KL-Yageo-datasheet-12592811.pdf?src-supplier=element14+APAC; ComponentLink9Description: https://datasheet.octopart.com:443/RC0805FR-0710KL-Yageo-Group-datasheet-190305687.pdf?src-supplier=Future+Electronics; ComponentLink9URL: https://datasheet.octopart.com:443/RC0805FR-0710KL-Yageo-Group-datasheet-190305687.pdf?src-supplier=Future+Electronics; ComponentLink10Description: https://datasheet.octopart.com:443/RC0805FR-0710KL-Yageo-datasheet-164759695.pdf?src-supplier=Future+Electronics; ComponentLink10URL: https://datasheet.octopart.com:443/RC0805FR-0710KL-Yageo-datasheet-164759695.pdf?src-supplier=Future+Electronics; ComponentLink11Description: https://datasheet.octopart.com:443/RC0805FR-0710KL-Yageo-datasheet-15800360.pdf?src-supplier=Future+Electronics; ComponentLink11URL: https://datasheet.octopart.com:443/RC0805FR-0710KL-Yageo-datasheet-15800360.pdf?src-supplier=Future+Electronics; ComponentLink12Description: https://datasheet.octopart.com:443/RC0805FR-0710KL-Yageo-datasheet-8324252.pdf?src-supplier=Future+Electronics; ComponentLink12URL: https://datasheet.octopart.com:443/RC0805FR-0710KL-Yageo-datasheet-8324252.pdf?src-supplier=Future+Electronics; ComponentLink13Description: https://datasheet.octopart.com:443/RC0805FR-0710KL-Yageo-datasheet-79472498.pdf?src-supplier=Megastar; ComponentLink13URL: https://datasheet.octopart.com:443/RC0805FR-0710KL-Yageo-datasheet-79472498.pdf?src-supplier=Megastar; ComponentLink14Description: https://datasheet.octopart.com:443/RC0805FR-0710KL-Yageo-datasheet-27714392.pdf?src-supplier=Seeed+Studio; ComponentLink14URL: https://datasheet.octopart.com:443/RC0805FR-0710KL-Yageo-datasheet-27714392.pdf?src-supplier=Seeed+Studio; ComponentLink15Description: https://datasheet.octopart.com/RC0805FR-0710KL-Yageo-datasheet-73766026.pdf; ComponentLink15URL: https://datasheet.octopart.com/RC0805FR-0710KL-Yageo-datasheet-73766026.pdf; ComponentLink16Description: https://datasheet.octopart.com:443/RC0805FR-0710KL-Yageo-datasheet-118657.pdf?src-supplier=DigiKey; ComponentLink16URL: https://datasheet.octopart.com:443/RC0805FR-0710KL-Yageo-datasheet-118657.pdf?src-supplier=DigiKey; ComponentLink17Description: https://datasheet.octopart.com:443/RC0805FR-0710KL-Yageo-datasheet-502840.pdf?src-supplier=element14; ComponentLink17URL: https://datasheet.octopart.com:443/RC0805FR-0710KL-Yageo-datasheet-502840.pdf?src-supplier=element14; ComponentLink18Description: http://sigma.octopart.com:80/conflict_mineral_statement/Yageo-company-3.pdf?src-supplier=Compliance+Statements; ComponentLink18URL: http://sigma.octopart.com:80/conflict_mineral_statement/Yageo-company-3.pdf?src-supplier=Compliance+Statements; ComponentLink19Description: http://sigma.octopart.com:80/rohs_statement/Yageo-company-2.pdf?src-supplier=Compliance+Statements; ComponentLink19URL: http://sigma.octopart.com:80/rohs_statement/Yageo-company-2.pdf?src-supplier=Compliance+Statements; Composition: Thick Film; Contact Plating: Tin; Country of Origin: Mainland China, Taiwan; Depth: 1.25mm; Export Control Classification Number (ECCN) Code: EAR99; Features: Moisture Resistant; Harmonized Tariff Schedule (HTS) Code: 8533.21.00.30; Height: 500µm; Introduction Date: 2004-09-22; Lead Free: Lead Free; Length: 2mm; Lifecycle Status: Production; Manufacturer Part Number: RC0805FR-0710KL; Max Operating Temperature: 155°C; Military Standard: Not; Min Operating Temperature: -55°C; Mounting Technology: Surface Mount; Number of Terminals: 2; Number of Terminations: 2; Packaging: Tape and Reel; Pins: 2; Power Rating: 125mW; Pricing: 1=0.1, 10=0.034, 25=0.0256, 50=0.0208, 100=0.0171, 250=0.01344, 500=0.01136, 1000=0.00973 (USD); Radiation Hardening: No; REACH SVHC: Unaffected; RoHS: Compliant; Schedule B: 8533210045, 8533210045|8533210045|8533210045|8533210045|8533210045|8533210045|8533210045|8533210045|8533210045|8533210045|8533210045|8533210045|8533210045|8533210045|8533210045|8533210045|8533210045|8533210045|8533210045|8533210045|8533210045|8533210045|8533210045|85; Stock: 1894048; Supplier: DigiKey; Supplier Part Number: 311-10.0KCRDKR-ND; Termination: SMD/SMT; Tolerance: 1%; Voltage Rating (AC): 150V; Voltage Rating (DC): 150V; Width: 1.25mm; Working Voltage: 150V; Library Name: Fuzz_Pedal_Lib.SchLib
R3 = CRCW0805649RFKEA: 125mW Thick Film Resistors 150V ±1% ±100ppm/℃ 649Ω 0805 Chip Resistor - Surface Mount ROHS; Manufacturer: Vishay; Manufacturer Package Identifier: CRCW0805-e3; Temperature Coefficient: 100ppm/°C; Case Code (Imperial): 0805; Case/Package: 0805; Category: Chip SMD Resistors; China RoHS: Non-Compliant; Component Kind: Standard; ComponentLink1Description: https://datasheet.octopart.com:443/CRCW0805649RFKEA-Vishay-datasheet-175423584.pdf?src-supplier=IHS; ComponentLink1URL: https://datasheet.octopart.com:443/CRCW0805649RFKEA-Vishay-datasheet-175423584.pdf?src-supplier=IHS; ComponentLink2Description: https://datasheet.octopart.com:443/CRCW0805649RFKEA-Vishay-datasheet-83961561.pdf?src-supplier=element14+APAC; ComponentLink2URL: https://datasheet.octopart.com:443/CRCW0805649RFKEA-Vishay-datasheet-83961561.pdf?src-supplier=element14+APAC; ComponentLink3Description: https://datasheet.octopart.com:443/CRCW0805649RFKEA-Vishay-datasheet-66077789.pdf?src-supplier=element14+APAC; ComponentLink3URL: https://datasheet.octopart.com:443/CRCW0805649RFKEA-Vishay-datasheet-66077789.pdf?src-supplier=element14+APAC; ComponentLink4Description: https://datasheet.octopart.com:443/CRCW0805649RFKEA-Vishay-datasheet-62317937.pdf?src-supplier=element14+APAC; ComponentLink4URL: https://datasheet.octopart.com:443/CRCW0805649RFKEA-Vishay-datasheet-62317937.pdf?src-supplier=element14+APAC; ComponentLink5Description: https://datasheet.octopart.com:443/CRCW0805649RFKEA-Vishay-datasheet-33013972.pdf?src-supplier=element14+APAC; ComponentLink5URL: https://datasheet.octopart.com:443/CRCW0805649RFKEA-Vishay-datasheet-33013972.pdf?src-supplier=element14+APAC; ComponentLink6Description: https://datasheet.octopart.com:443/CRCW0805649RFKEA-Vishay-datasheet-14722531.pdf?src-supplier=element14+APAC; ComponentLink6URL: https://datasheet.octopart.com:443/CRCW0805649RFKEA-Vishay-datasheet-14722531.pdf?src-supplier=element14+APAC; ComponentLink7Description: https://datasheet.octopart.com:443/CRCW0805649RFKEA.-Vishay-datasheet-78776209.pdf?src-supplier=Newark; ComponentLink7URL: https://datasheet.octopart.com:443/CRCW0805649RFKEA.-Vishay-datasheet-78776209.pdf?src-supplier=Newark; ComponentLink8Description: https://datasheet.octopart.com:443/CRCW0805649RFKEA-Vishay-datasheet-11761042.pdf?src-supplier=Newark; ComponentLink8URL: https://datasheet.octopart.com:443/CRCW0805649RFKEA-Vishay-datasheet-11761042.pdf?src-supplier=Newark; ComponentLink9Description: https://datasheet.octopart.com:443/CRCW0805649RFKEA-Vishay-datasheet-11516975.pdf?src-supplier=Newark; ComponentLink9URL: https://datasheet.octopart.com:443/CRCW0805649RFKEA-Vishay-datasheet-11516975.pdf?src-supplier=Newark; ComponentLink10Description: https://datasheet.octopart.com:443/CRCW0805649RFKEA-Vishay-datasheet-41302739.pdf?src-supplier=Newark; ComponentLink10URL: https://datasheet.octopart.com:443/CRCW0805649RFKEA-Vishay-datasheet-41302739.pdf?src-supplier=Newark; ComponentLink11Description: https://datasheet.octopart.com:443/CRCW0805649RFKEA-Vishay-datasheet-26590290.pdf?src-supplier=Farnell; ComponentLink11URL: https://datasheet.octopart.com:443/CRCW0805649RFKEA-Vishay-datasheet-26590290.pdf?src-supplier=Farnell; ComponentLink12Description: https://datasheet.octopart.com:443/CRCW0805649RFKEA-Vishay-datasheet-62316122.pdf?src-supplier=Future+Electronics; ComponentLink12URL: https://datasheet.octopart.com:443/CRCW0805649RFKEA-Vishay-datasheet-62316122.pdf?src-supplier=Future+Electronics; ComponentLink13Description: https://datasheet.octopart.com:443/CRCW0805649RFKEA-Vishay-Dale-datasheet-47688880.pdf?src-supplier=RS+(Formerly+Allied+Electronics); ComponentLink13URL: https://datasheet.octopart.com:443/CRCW0805649RFKEA-Vishay-Dale-datasheet-47688880.pdf?src-supplier=RS+(Formerly+Allied+Electronics); ComponentLink14Description: https://datasheet.octopart.com:443/CRCW0805649RFKEA-Vishay-datasheet-12001583.pdf?src-supplier=RS+(Formerly+Allied+Electronics); ComponentLink14URL: https://datasheet.octopart.com:443/CRCW0805649RFKEA-Vishay-datasheet-12001583.pdf?src-supplier=RS+(Formerly+Allied+Electronics); ComponentLink15Description: https://datasheet.octopart.com:443/CRCW0805649RFKEA-Vishay-datasheet-5306405.pdf?src-supplier=RS+(Formerly+Allied+Electronics); ComponentLink15URL: https://datasheet.octopart.com:443/CRCW0805649RFKEA-Vishay-datasheet-5306405.pdf?src-supplier=RS+(Formerly+Allied+Electronics); ComponentLink16Description: https://datasheet.octopart.com:443/CRCW0805649RFKEA-Vishay-datasheet-11540273.pdf?src-supplier=Arrow+Electronics; ComponentLink16URL: https://datasheet.octopart.com:443/CRCW0805649RFKEA-Vishay-datasheet-11540273.pdf?src-supplier=Arrow+Electronics; ComponentLink17Description: https://datasheet.octopart.com:443/CRCW0805649RFKEA-Vishay-datasheet-8445837.pdf?src-supplier=element14; ComponentLink17URL: https://datasheet.octopart.com:443/CRCW0805649RFKEA-Vishay-datasheet-8445837.pdf?src-supplier=element14; ComponentLink18Description: https://datasheet.octopart.com:443/CRCW0805649RFKEA-Vishay-datasheet-5377306.pdf?src-supplier=Garrett; ComponentLink18URL: https://datasheet.octopart.com:443/CRCW0805649RFKEA-Vishay-datasheet-5377306.pdf?src-supplier=Garrett; ComponentLink19Description: https://datasheet.octopart.com:443/CRCW0805649RFKEA-Vishay-datasheet-148188.pdf?src-supplier=Garrett; ComponentLink19URL: https://datasheet.octopart.com:443/CRCW0805649RFKEA-Vishay-datasheet-148188.pdf?src-supplier=Garrett; ComponentLink20Description: https://datasheet.octopart.com:443/CRCW0805649RFKEA-Vishay-datasheet-34203.pdf?src-supplier=Garrett; ComponentLink20URL: https://datasheet.octopart.com:443/CRCW0805649RFKEA-Vishay-datasheet-34203.pdf?src-supplier=Garrett; ComponentLink21Description: http://sigma.octopart.com:80/rohs_statement/Vishay-company-15.pdf?src-supplier=Compliance+Statements; ComponentLink21URL: http://sigma.octopart.com:80/rohs_statement/Vishay-company-15.pdf?src-supplier=Compliance+Statements; Composition: Thick Film; Contact Plating: Tin; Country of Origin: Israel; Depth: 1.25mm; Export Control Classification Number (ECCN) Code: EAR99; Features: Automotive AEC-Q200; Harmonized Tariff Schedule (HTS) Code: 8533.21.00.30; Height: 450µm; Introduction Date: 2005-02-16; Lead Free: Lead Free; Length: 2mm; Lifecycle Status: Production; Manufacturer Part Number: CRCW0805649RFKEA; Max Operating Temperature: 155°C; Max Power Dissipation: 125mW; Military Standard: Not; Min Operating Temperature: -55°C; Mounting Technology: Surface Mount; Number of Terminals: 2; Number of Terminations: 2; Packaging: Cut Tape; Pins: 2; Power Rating: 125mW; Pricing: 1=0.1, 10=0.044, 25=0.0332, 50=0.0272, 100=0.0225, 250=0.01796, 500=0.01534, 1000=0.01329 (USD); Radiation Hardening: No; Ratings: AEC-Q200; REACH SVHC: Unaffected; RoHS: Compliant; Schedule B: 8533210045, 8533210045|8533210045, 8533210045|8533210045|8533210045, 8533210045|8533210045|8533210045|8533210045; Stock: 23385; Supplier: DigiKey; Supplier Part Number: 541-649CCT-ND; Termination: SMD/SMT; Tolerance: 1%; Value: 649Ω; Voltage Rating: 150V; Voltage Rating (DC): 150V; Weight: 5.499807mg; Width: 1.25mm; Working Voltage: 150V; Library Name: Fuzz_Pedal_Lib.SchLib
